# Altium schematic sheet 电源及串口部分.SchDoc
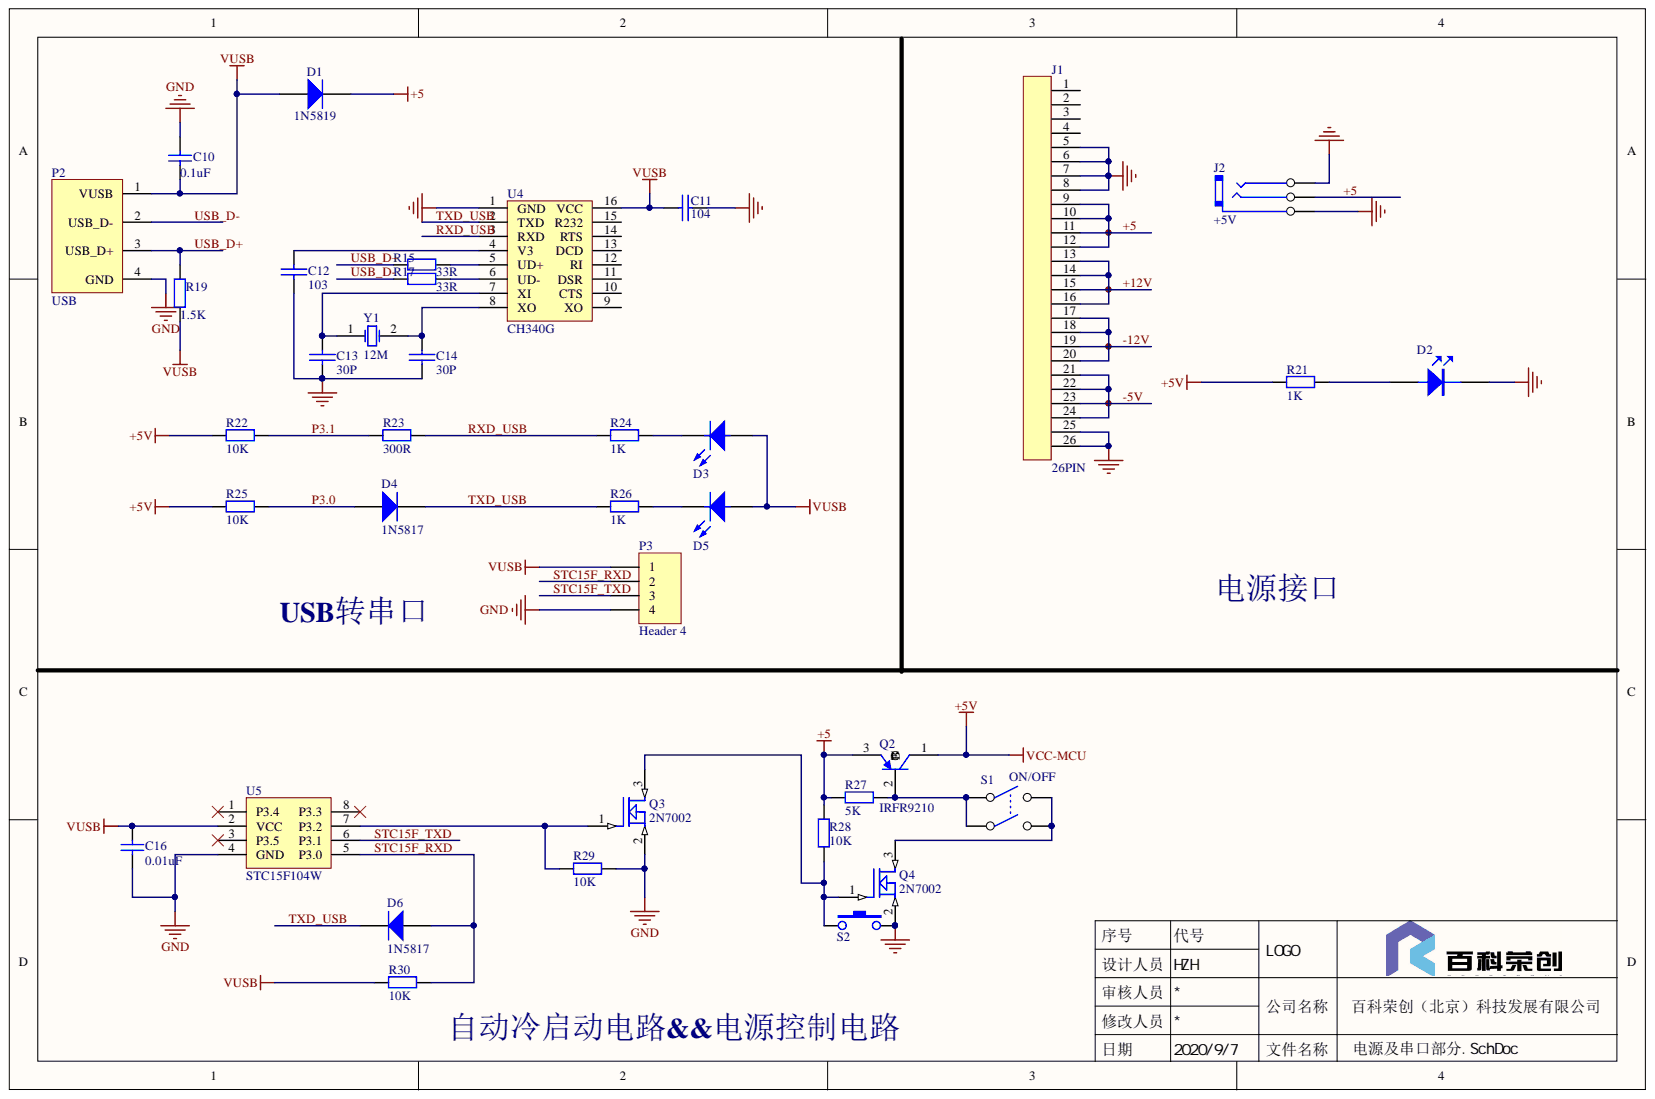
[diagram p0: 电源及串口部分.SchDoc]

## Parts
C10  0.1uF  [C0603]
C11  104  [C0603]
C12  103  [C0603]
C13  30P  [C0603]
C14  30P  [C0603]
C16  0.01uF  [C0603]
D1  1N5819  [SOD123]
D2  LED-R3  [LED_0603]  (value not drawn)
D3  LED  [LED_0603]  (value not drawn)
D4  1N5817  [SOD123]
D5  LED  [LED_0603]  (value not drawn)
D6  1N5817  [SOD123]
J1  26PIN  [IDC26]
J2  +5V  [JACK2]
P2  USB  [USB_B1-180]
P3  Header 4  [HDR1X4]
Q2  IRFR9210  [2SB1204]
Q3  2N7002  [SOT-23]
Q4  2N7002  [SOT-23]
R15  33R  [R0603]
R17  33R  [R0603]
R19  1.5K  [R0603]
R21  1K  [R0603]
R22  10K  [R0603]
R23  300R  [R0603]
R24  1K  [R0603]
R25  10K  [R0603]
R26  1K  [R0603]
R27  5K  [R0603]
R28  10K  [R0603]
R29  10K  [R0603]
R30  10K  [R0603]
S1  ON/OFF  [SW-OUT]
S2  SW-PB  [KEY6*6]  (value not drawn)
U4  CH340G  [SOP16]
U5  STC15F104W  [SOP-8]
Y1  12M  [NX5032]

## Nets
+5V: Q2.1 R21.2 R22.1 R25.1
GND: C10.2 C11.2 C12.2 C13.1 C14.1 C16.2 D2.2 J1.5 J1.6 J1.7 J1.8 J1.25 J1.26 J2.1 J2.3 P2.4(GND) P3.4 Q3.2 Q4.2 R29.1 S2.2 U4.1(GND) U5.4(GND)
VUSB: C10.1 C11.1 C16.1 D1.1 D3.1 D5.1 P2.1(VUSB) P3.1 R19.1 R30.1 U4.16(VCC) U5.2(VCC)
+5: D1.2 J1.9 J1.10 J1.11 J1.12 J2.2 Q2.3 R27.2 R28.1
+12V: J1.13 J1.14 J1.15 J1.16
-5V: J1.21 J1.22 J1.23 J1.24
-12V: J1.17 J1.18 J1.19 J1.20
P3.0: D4.1 R25.2
P3.1: R22.2 R23.1
RXD_USB: R23.2 R24.2 U4.3(RXD)
STC15F_RXD: D6.1 P3.2 R30.2 U5.5(P3.0)
STC15F_TXD: P3.3 U5.6(P3.1)
TXD_USB: D4.2 D6.2 R26.2 U4.2(TXD)
USB_D+: P2.3(USB_D+) R15.1 R19.2
USB_D-: P2.2(USB_D-) R17.1
NetC12_1: C12.1 U4.4(V3)
NetC13_2: C13.2 U4.7(XI) Y1.1
NetC14_2: C14.2 U4.8(XO) Y1.2
NetD2_1: D2.1 R21.1
NetD3_2: D3.2 R24.1
NetD5_2: D5.2 R26.1
NetQ2_2: Q2.2 R27.1 S1.1 S1.2
NetQ3_1: Q3.1 R29.2 U5.7(P3.2)
NetQ3_3: Q3.3 Q4.1 R28.2 S2.1
NetQ4_3: Q4.3 S1.3 S1.4
NetR15_2: R15.2 U4.5(UD+)
NetR17_2: R17.2 U4.6(UD-)

Not connected: J1 1 2 3 4; U4 9(XO) 10(CTS) 11(DSR) 12(RI) 13(DCD) 14(RTS) 15(R232); U5 1(P3.4) 3(P3.5) 8(P3.3)

## Library data of the parts
C10 = Cap: Capacitor; Value: 104; Component Kind: Standard; LatestRevisionDate: 17-Jul-2002; LatestRevisionNote: Re-released for DXP Platform.; Library Name: Miscellaneous Devices.IntLib; PackageReference: RAD-0.3; Published: 8-Jun-2000; Signal Integrity: Cap; Simulation: CAP; Publisher: Altium Limited
C11-C14 = CAP: Capacitor; Component Kind: Standard
C16 = Cap: Capacitor; Component Kind: Standard; LatestRevisionDate: 17-Jul-2002; LatestRevisionNote: Re-released for DXP Platform.; Library Name: Miscellaneous Devices.IntLib; PackageDescription: Radial Cap, Thru-Hole; 2 Leads; 0.3 in Pin Spacing; PackageReference: RAD-0.3; Published: 8-Jun-2000; Signal Integrity: Cap; Simulation: CAP; Value: 0.01uF; Publisher: Altium Limited
D1 = Diode 1N4001: 1 Amp General Purpose Rectifier; Code_JEDEC: DO-204-AL; Component Kind: Standard; ComponentLink1Description: Manufacturer Link; ComponentLink1URL: http://www.fairchildsemi.com/; ComponentLink2Description: Datasheet; ComponentLink2URL: http://www.fairchildsemi.com/ds/1N%2F1N4001.pdf; DatasheetDocument: 1998; LatestRevisionDate: 17-Jul-2002; LatestRevisionNote: Re-released for DXP Platform.; PackageDocument: Aug-1999; PackageReference: DO-41; Published: 8-Jun-2000; Publisher: Altium Limited
D2 = LED: Component Kind: Standard
D4 D6 = Diode 1N5400: 3 Amp General Purpose Rectifier; Component Kind: Standard; ComponentLink1Description: Manufacturer Link; ComponentLink1URL: http://www.fairchildsemi.com/; ComponentLink2Description: Datasheet; ComponentLink2URL: http://www.fairchildsemi.com/ds/1N%2F1N5400.pdf; DatasheetVersion: 1998; LatestRevisionDate: 17-Jul-2002; LatestRevisionNote: Re-released for DXP Platform.; Library Name: Miscellaneous Devices.IntLib; PackageDescription: Diode, Axial; Body 9.5 x 5.6 mm (LxDia. max), Lead Dia. 1.32 mm (max); PackageReference: DO-201AD; PackageVersion: Aug-1999; Published: 8-Jun-2000; Signal Integrity: 1N5400; Simulation: 1N5400; Publisher: Altium Limited
J2 = PHONEJACK2: Component Kind: Standard
P2 = USB_mini: Component Kind: Standard; Library Name: 通用原理图_1.SchLib
P3 = Header 4: Header, 4-Pin; Component Kind: Standard; LatestRevisionDate: 17-Jul-2002; LatestRevisionNote: Re-released for DXP Platform.; Library Name: Miscellaneous Connectors.IntLib; Signal Integrity: Connector; Publisher: Altium Limited
Q2 = PNP: PNP 功率三极管; Code_JEDEC: SOT-23; Component Kind: Standard; LatestRevisionDate: 17-Jul-2002; LatestRevisionNote: Re-released for DXP Platform.; Library Name: Miscellaneous Devices.IntLib; PackageReference: SOT-23B; Published: 8-Jun-2000; Signal Integrity: PNP; Simulation: PNP; Publisher: Altium Limited
Q3 Q4 = MOSFET N: Component Kind: Standard; Library Name: Miscellaneous Devices.SCHLIB
R15 R17 R21 R22 R24-R26 = Res2: Resistor; Component Kind: Standard; LatestRevisionDate: 17-Jul-2002; LatestRevisionNote: Re-released for DXP Platform.; Library Name: Miscellaneous Devices.IntLib; PackageReference: AXIAL-0.4; Published: 8-Jun-2000; Signal Integrity: Res; Simulation: RESISTOR; Publisher: Altium Limited
  R15 R17: Value: 33R
  R21 R24 R26: PackageDescription: Axial Device, Thru-Hole; 2 Leads; 0.4 in Pin Spacing; Value: 1K
  R22 R25: PackageDescription: Axial Device, Thru-Hole; 2 Leads; 0.4 in Pin Spacing; Value: 10K
R19 = Res2: Resistor; Value: 1K5; Component Kind: Standard; LatestRevisionDate: 17-Jul-2002; LatestRevisionNote: Re-released for DXP Platform.; Library Name: Miscellaneous Devices.IntLib; PackageReference: AXIAL-0.4; Published: 8-Jun-2000; Signal Integrity: Res; Simulation: RESISTOR; Publisher: Altium Limited
R23 R27-R30 = Res2: Resistor; Value: 1K; Component Kind: Standard; LatestRevisionDate: 17-Jul-2002; LatestRevisionNote: Re-released for DXP Platform.; Library Name: Miscellaneous Devices.IntLib; PackageDescription: Axial Device, Thru-Hole; 2 Leads; 0.4 in Pin Spacing; PackageReference: AXIAL-0.4; Published: 8-Jun-2000; Signal Integrity: Res; Simulation: RESISTOR; Publisher: Altium Limited
S1 = SW-DPST: Component Kind: Standard
U4 = CH347G: Component Kind: Standard; Library Name: 原理图库.SchLib
Y1 = XTAL: Crystal Oscillator; Component Kind: Standard; LatestRevisionDate: 17-Jul-2002; LatestRevisionNote: Re-released for DXP Platform.; Library Name: Miscellaneous Devices.IntLib; PackageReference: R38; Published: 8-Jun-2000; Simulation: XTAL; Publisher: Altium Limited
D3 D5 = LED: Component Kind: Standard; Library Name: 通用原理图.SchLib
J1 = 26PIN: Component Kind: Standard
S2 = SW-PB: Component Kind: Standard
U5 = STC15F104W: Component Kind: Standard; Library Name: 通用原理图.SchLib
